# Altium schematic sheet 14-Power-P1V8.SchDoc(14-Power-P1V8)
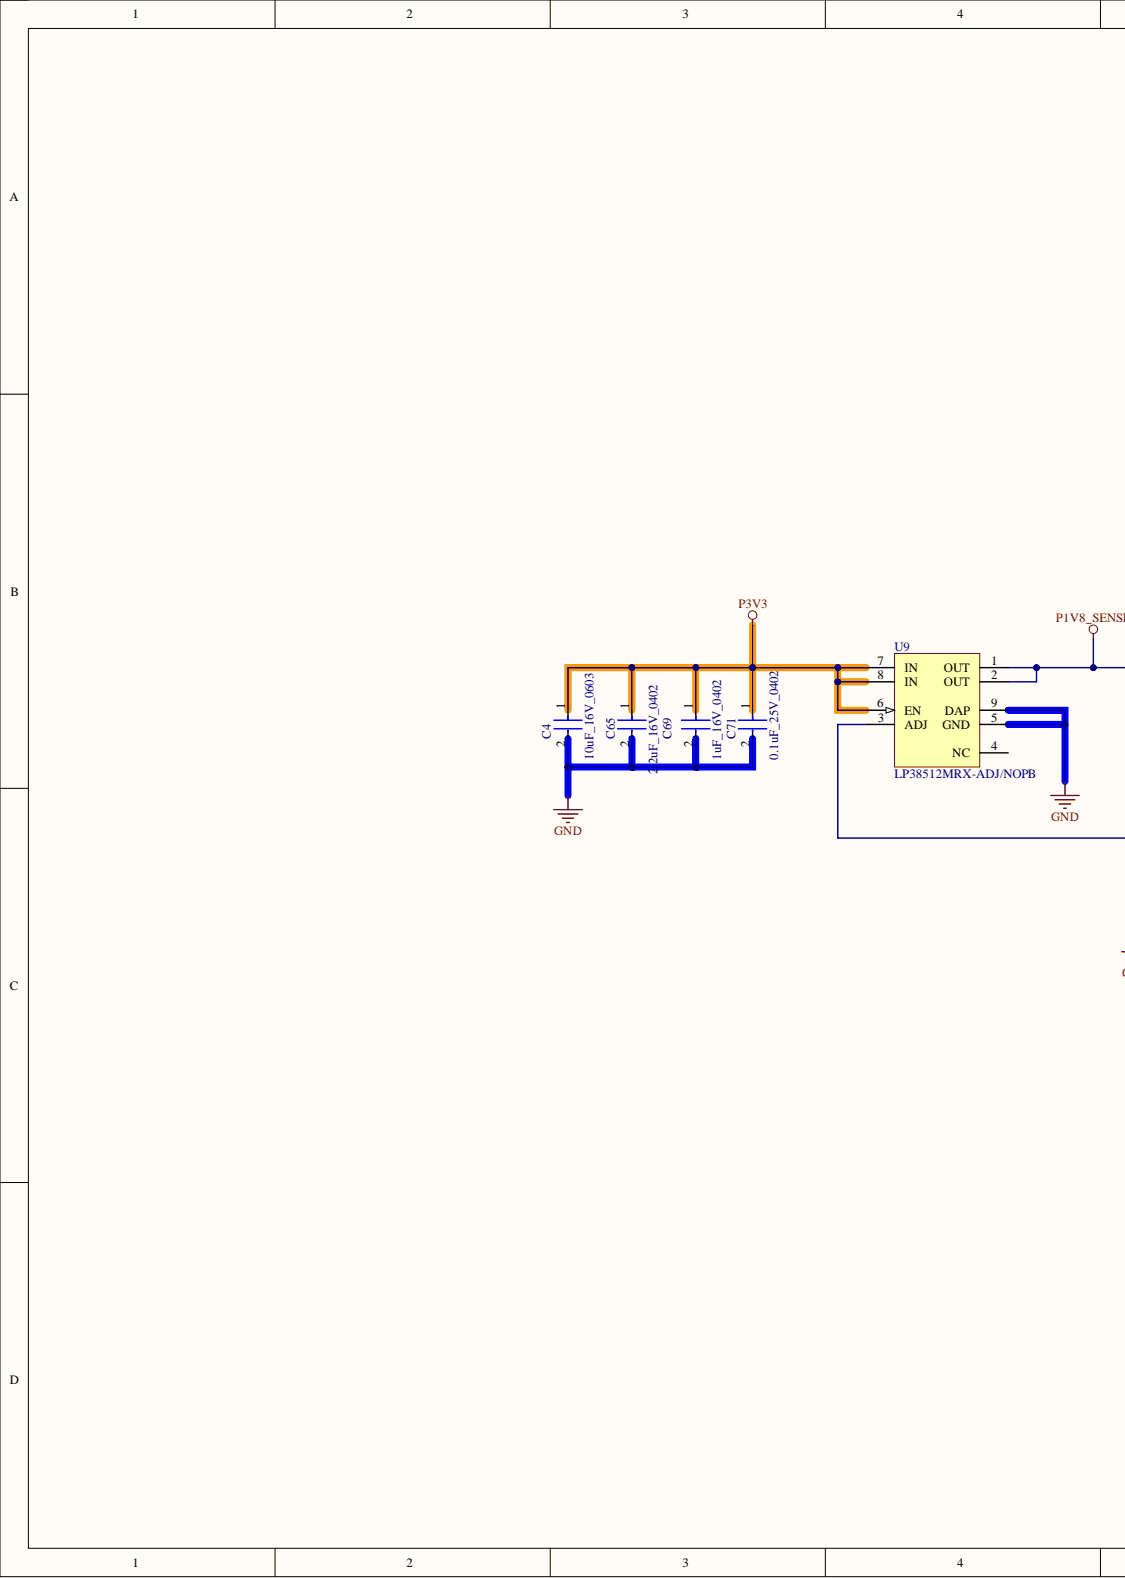
[diagram p0: 14-Power-P1V8.SchDoc, left]
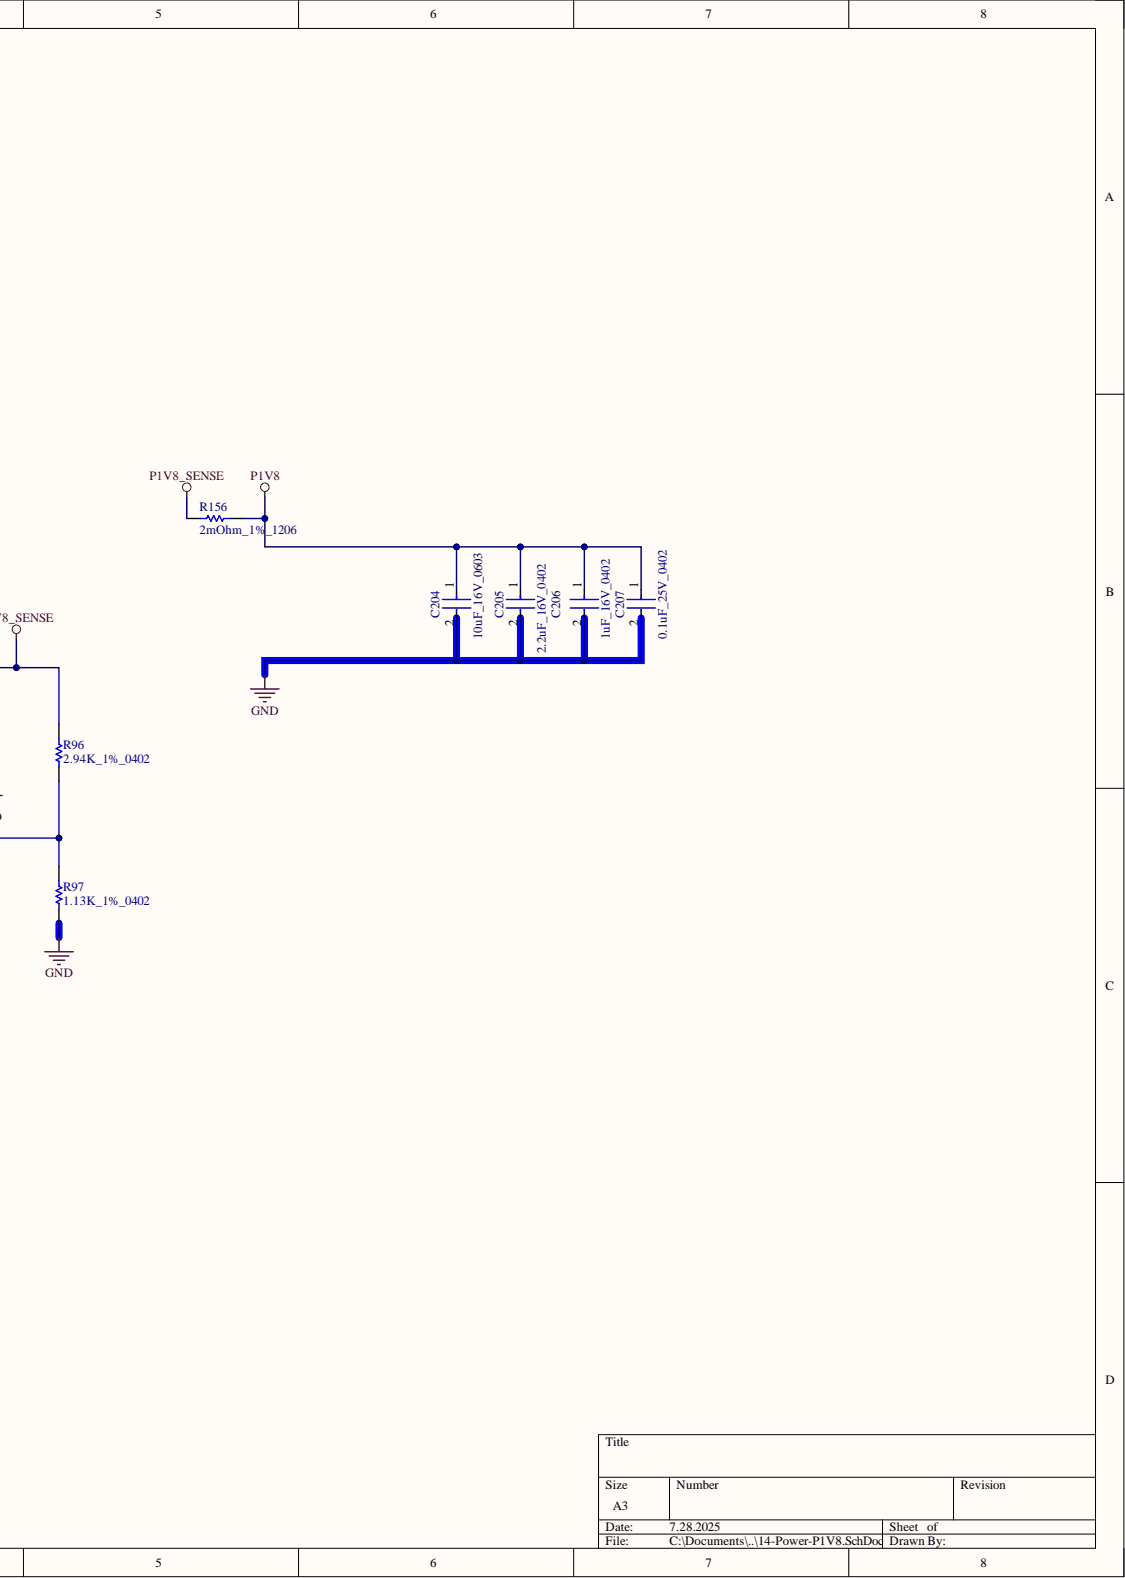
[diagram p1: 14-Power-P1V8.SchDoc, right]

## Parts
C4  10uF_16V_0603  [FP-0603-L_1_6_0_2-W_0_8_0-MFG]
C65  2.2uF_16V_0402  [FP-C1005-050-0_05-IPC_A]
C69  1uF_16V_0402  [FP-0402-L_1_0_0_05-W_0_5-IPC_A]
C71  0.1uF_25V_0402  [FP-0402-L_1_0_1-W_0_5_0_1-IPC_C]
C204  10uF_16V_0603  [FP-0603-L_1_6_0_2-W_0_8_0-MFG]
C205  2.2uF_16V_0402  [FP-C1005-050-0_05-IPC_A]
C206  1uF_16V_0402  [FP-0402-L_1_0_0_05-W_0_5-IPC_A]
C207  0.1uF_25V_0402  [FP-0402-L_1_0_1-W_0_5_0_1-IPC_C]
R96  2.94K_1%_0402  [RESC1005X40X25ML05T10]
R97  1.13K_1%_0402  [RESC1005X40X25NL05T05]
R156  2mOhm_1%_1206  [RESC3216X89X64NL25T25]
U9  LP38512MRX-ADJ/NOPB  [MRA08B_M]

## Nets
GND: C4.2 C65.2 C69.2 C71.2 C204.2 C205.2 C206.2 C207.2 R97.1 U9.5(GND) U9.9(DAP)
P1V8: C204.1 C205.1 C206.1 C207.1 R156.2
P1V8_SENSE: R96.2 R156.1 U9.1(OUT) U9.2(OUT)
P3V3: C4.1 C65.1 C69.1 C71.1 U9.6(EN) U9.7(IN) U9.8(IN)
NetR96_1: R96.1 R97.2 U9.3(ADJ)

Not connected: U9 4(NC)

## Library data of the parts
C4 C204 = CMP-2000-06226-4: Multilayer Ceramic Capacitor 10uF 16V X5R 20% SMD 0603 T/R; Capacitance: 10uF; Case Code (Imperial): 0603; Case Code (Metric): 1608; Case/Package: 0603; Component Kind: Standard; Construction: Flat; Dielectric: X5R; Halogen Free: Halogen Free; Height: 0.8mm; Length: 0.063inch; Library Name: Altium Content Vault; Max Operating Temperature: 85°C; Min Operating Temperature: -55°C; Mount: Surface Mount; Package Quantity: 1; Packaging: Tape and Reel; RoHS Compliant: Yes; Series: M; Termination: SMD/SMT; Thickness: 0.031inch; Tolerance: 20%; Voltage Rating: 16V; Voltage Rating (DC): 16V; Width: 0.031inch
C65 C205 = CMP-2000-05998-2: Multilayer Ceramic Capacitors 2.2μF ±10% 16V X5R SMD 0402; Capacitance: 2.2uF; Case Code (Imperial): 0402; Case Code (Metric): 1005; Case/Package: 0402; Component Kind: Standard; Depth: 0.5mm; Dielectric: X5R; Dielectric Material: Ceramic; Height: 0.5mm; Lead Free: Lead Free; Length: 1mm; Library Name: Altium Content Vault; Max Operating Temperature: 85°C; Min Operating Temperature: -55°C; Mount: Surface Mount; Package Quantity: 1; Packaging: Tape and Reel; REACH SVHC: No SVHC; Resistor Type: Ceramic; RoHS Compliant: Yes; Series: C; Termination: SMD/SMT; Thickness: 0.022inch; Tolerance: 10%; Voltage Rating: 16V; Voltage Rating (DC): 16V; Weight: 2.3E-05oz; Width: 0.02inch
C69 C206 = CMP-14477-000104-2: None; Capacitance: 1uF; Dielectric: X5R; Tolerance: 10%; Voltage Rating: 16V; Case Code (Imperial): 0402; Case Code (Metric): 1005; Case/Package: 0402; Component Kind: Standard; Construction: Flat; Depth: 0.5mm; Halogen Free: Halogen Free; Height: 0.5mm; Length: 1mm; Library Name: Altium Content Vault; Max Operating Temperature: 85°C; Min Operating Temperature: -55°C; Mount: Surface Mount; Number of Pins: 2; Package Quantity: 1; Packaging: Tape and Reel; Radiation Hardening: No; RoHS Compliant: Yes; Series: M; Simulation: EMK105BJ105_V-F; Termination: SMD/SMT; Thickness: 0.022inch; Voltage Rating (DC): 16V; Width: 0.02inch
C71 C207 = CMP-2008-02519-2: General Purpose Ceramic Capacitor, 0402, 100nF, 10%, X7R, 15%, 25V; Capacitance: 100nF; Case Code (Imperial): 0402; Case Code (Metric): 1005; Case/Package: 0402; Component Kind: Standard; Construction: Flat; Depth: 0.5mm; Dielectric: X7R; Lead Free: Lead Free; Length: 1mm; Library Name: Altium Content Vault; Max Operating Temperature: 125°C; Min Operating Temperature: -55°C; Mount: Surface Mount; Number of Pins: 2; Package Quantity: 1; Packaging: Tape and Reel; Radiation Hardening: No; REACH SVHC: No SVHC; RoHS Compliant: Yes; Series: -; Termination: SMD/SMT; Thickness: 0.022inch; Tolerance: 10%; Voltage: 25V; Voltage Rating: 25V; Voltage Rating (DC): 25V; Width: 0.02inch
R96 = CMP-2002-07579-1: Manufacturer: Yageo; Part Number: RC0402FR-072K94L; Component Kind: Standard; Library Name: Altium Content Vault
R97 = CMP-2002-00630-1: Manufacturer: Panasonic; Part Number: ERJ-2RKF1131X; Component Kind: Standard; Library Name: Altium Content Vault
R156 = CMP-2003-04334-1: Manufacturer: Vishay Dale; Part Number: WSL12062L000FEA18; Component Kind: Standard; Library Name: Altium Content Vault
U9 = CMP-0062-01039-2: 1.5A Fast-Transient Response Adjustable Low-Dropout Linear Voltage Regulator, 8-pin PSOP, Pb-Free; Manufacturer: National Semiconductor; CompType: LDO IC; PackageTopMarking: NSXYTT*L38512*-ADJ; PowerWise: N; Code_JEDEC: MS-012-AA; Component Kind: Standard; ComponentLink1Description: LP38512 Datasheet; ComponentLink1URL: http://www.national.com/ds/LP/LP38512-ADJ.pdf; ComponentLink2Description: LP38512 Web Page; ComponentLink2URL: http://www.national.com/pf/LP/LP38512.html; ComponentLink3Description: MRA08B Package Page; ComponentLink3URL: http://www.national.com/packaging/folders/mra08b.html; DatasheetVersion: Feb-2009; Library Name: Altium Content Vault; PackageDescription: Molded, PSOP .193 x .154 x .058in, 8 Lead, .050in Pitch, Large DAP; PackageReference: MRA08B; PackageVersion: revB, Dec-2007; PinCount: 8; RevisionNote: Component URLs added; RoHS: Y
